# Altium schematic sheet PowerSupply.SchDoc
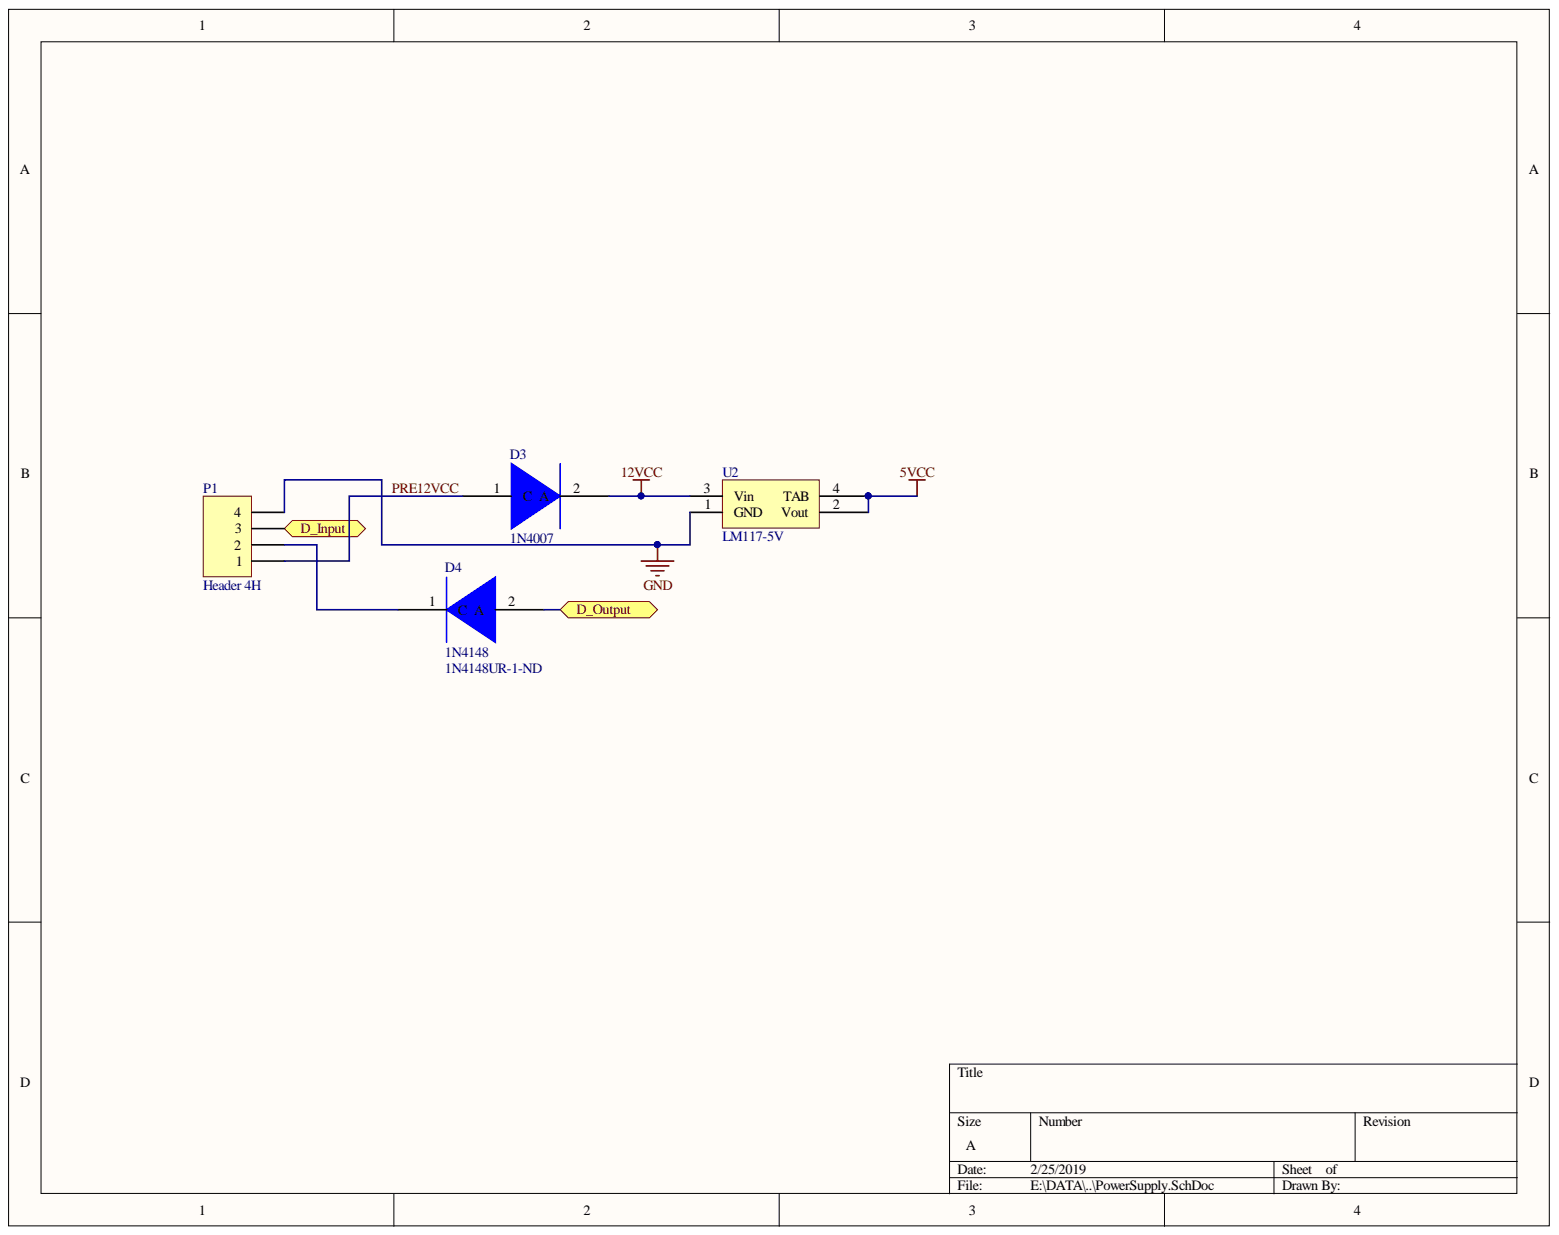
[diagram p0: PowerSupply.SchDoc]

## Parts
D3  1N4007  [SODFL504X244-2N]
D4  1N4148  Supplier Part Number 1: 1N4148UR-1-ND  [DIOMELF3515N]
P1  Header 4H  [HDR1X4H]
U2  LM117-5V  [SOT230P700X180-4N]

## Ports
D_Input, D_Output

## Nets
5VCC: U2.2(Vout) U2.4(TAB)
12VCC: D3.2 U2.3(Vin)
GND: P1.4 U2.1(GND)
D_Input: P1.3 ; port D_Input
D_Output: D4.2 ; port D_Output
PRE12VCC: D3.1 P1.1
NetD4_1: D4.1 P1.2

## Library data of the parts
D3 = 1N4007_SMD Diode: 1N4007_SMD; Component Kind: Standard; Library Name: Integrated_Library1.IntLib
D4 = 1N4148_SMD: DIODE GEN PURP 75V 200MA DO213AA; Component Kind: Standard; Library Name: Integrated_Library1.IntLib; Supplier 1: Digi-Key
P1 = Header 4H: Header, 4-Pin, Right Angle; Component Kind: Standard; LatestRevisionDate: 17-Jul-2002; LatestRevisionNote: Re-released for DXP Platform.; Library Name: Miscellaneous Connectors.IntLib; Signal Integrity: Connector; Publisher: Altium Limited
U2 = LM117-5V: Component Kind: Standard; Description: LM117-5V; Library Name: Integrated_Library1.IntLib
